# Altium schematic sheet encoderBreakout1.SchDoc
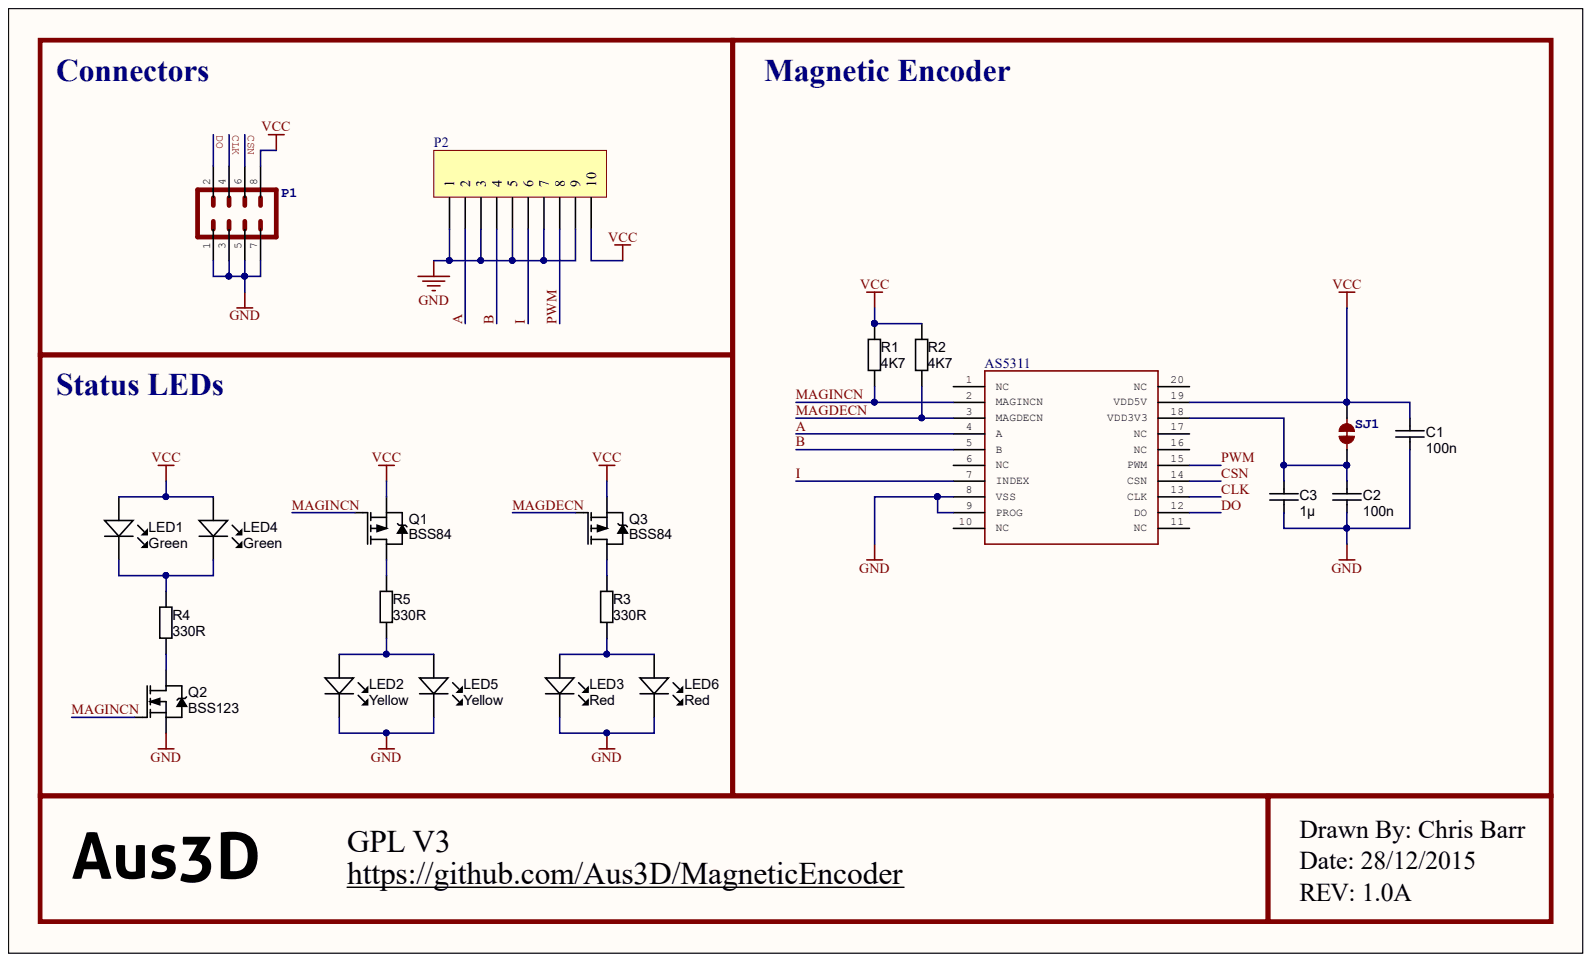
[diagram p0: encoderBreakout1.SchDoc]

## Parts
Aus3D  Aus3D  [Aus3D-Logo]
C1  value 100n  [CAPC1608X90N]  (hidden comment: approved sub OK)
C2  value 100n  [CAPC1608X90N]  (hidden comment: approved sub OK)
C3  value 1µ  [CAPC1608X90N]  (hidden comment: approved sub OK)
LED1  Green  [LED-0603-GREEN]
LED2  Yellow  [LED-0603-YELLOW]
LED3  Red  [LED-0603-RED]
LED4  Green  [LED-0603-GREEN]
LED5  Yellow  [LED-0603-YELLOW]
LED6  Red  [LED-0603-RED]
P1  .1" header, two rows of four.  [2X4]  (value not drawn)
P2  Header 10  [HDR1X10]  (value not drawn)
Q1  BSS84  [SOT23-3]
Q2  BSS123  [SOT23-3]
Q3  BSS84  [SOT23-3]
R1  value 4K7  [RESC1608X55N]  (hidden comment: Thick Film)
R2  value 4K7  [RESC1608X55N]  (hidden comment: Thick Film)
R3  value 330R  [RESC1608X55N]  (hidden comment: Thick Film)
R4  value 330R  [RESC1608X55N]  (hidden comment: Thick Film)
R5  value 330R  [RESC1608X55N]  (hidden comment: Thick Film)
SJ1  JUMPER-PAD-2-NC_BY_TRACE  [PAD-JUMPER-2-NC_BY_TRACE_YES_SILK]  (value not drawn)
U1  AS5311  [TSSOP-20]

## Nets
GND: C1.2 C2.2 C3.2 LED2.K LED3.K LED5.K LED6.K P1.1 P1.3 P1.5 P1.7 P2.1 P2.3 P2.5 P2.7 P2.9 Q2.2 U1.8(VSS) U1.9(PROG)
VCC: C1.1 LED1.A LED4.A P1.8 P2.10 Q1.2 Q3.2 R1.1 R2.1 SJ1.2 U1.19(VDD5V)
A: P2.2 U1.4(A)
B: P2.4 U1.5(B)
CLK: P1.4 U1.13(CLK)
CSN: P1.6 U1.14(CSN)
DO: P1.2 U1.12(DO)
I: P2.6 U1.7(INDEX)
MAGDECN: Q3.1 R2.2 U1.3(MAGDECN)
MAGINCN: Q1.1 Q2.1 R1.2 U1.2(MAGINCN)
PWM: P2.8 U1.15(PWM)
NetC2_1: C2.1 C3.1 SJ1.1 U1.18(VDD3V3)
NetLED1_K: LED1.K LED4.K R4.1
NetLED2_A: LED2.A LED5.A R5.2
NetLED3_A: LED3.A LED6.A R3.2
NetQ1_3: Q1.3 R5.1
NetQ2_3: Q2.3 R4.2
NetQ3_3: Q3.3 R3.1

Not connected: U1 1(NC) 6(NC) 10(NC) 11(NC) 16(NC) 17(NC) 20(NC)

## Library data of the parts
Aus3D = Aus3D Logo: Component Kind: Standard; Library Name: Schlib1.SchLib
C1 C2 = CAPC_100n_0603_X7R_50V_10%: Capacitor SMD Cer 100nF 10% 50V X7R 0603; Manufacturer 1: AVX Corporation; Manufacturer Part Number 1: 06035C104KAT2A; Material: ceramic; Ratings: 50V; X7R; SCDType: spec; Component Kind: Standard; ComponentLink1Description: http://www.avx.com/docs/Catalogs/cx7r.pdf; ComponentLink1URL: http://www.avx.com/docs/Catalogs/cx7r.pdf; ComponentLink2Description: http://product.tdk.com/capacitor/mlcc/en/documents/mlcc_commercial_general_en.pdf; ComponentLink2URL: http://product.tdk.com/capacitor/mlcc/en/documents/mlcc_commercial_general_en.pdf; Library Name: PBL - Capacitors.SCHLIB; Manufacturer 2: TDK Corporation; Manufacturer Part Number 2: C1608X7R1H104K; Package / Case: 0603 (1608 Metric); Supplier 1: Digi-Key; Supplier 2: Digi-Key; Supplier Part Number 1: 478-5052-1-ND; Supplier Part Number 2: 445-1314-1-ND; Tolerance: ±10%
C3 = CAPC_1u_0603_X7R_16V_10%: Capacitor SMD Cer 1uF 10% 16V X7R 0603; Manufacturer 1: TDK Corporation; Manufacturer Part Number 1: C1608X7R1C105K; Material: ceramic; Ratings: 16V; X7R; SCDType: spec; Component Kind: Standard; ComponentLink1Description: http://product.tdk.com/capacitor/mlcc/en/documents/mlcc_commercial_general_en.pdf; ComponentLink1URL: http://product.tdk.com/capacitor/mlcc/en/documents/mlcc_commercial_general_en.pdf; Library Name: PBL - Capacitors.SCHLIB; Package / Case: 0603 (1608 metric); Supplier 1: Digi-Key; Supplier Part Number 1: 445-1604-1-ND; Tolerance: ±10%
LED1 LED4 = LED_SMD_Green_0603_570nm: LED 0603 SMD Green 570nm 50mcd 20mA; Manufacturer 1: Kingbright Company LLC; Manufacturer Part Number 1: APT1608CGCK; Lens Style/Size: Rectangle with Flat Top, 1.1mm x 0.8mm; Lens Type: Clear; Material: AlGaInP; Ratings: 20mcd min; 50 mcd typ; 570 nm; SCDType: source; Viewing Angle: 120°; Voltage - Forward (Vf) @ 10mA: 2.0V; Voltage - Forward (Vf) Typ: 2.1V; Wavelength - Peak: 574nm; Color: Green; Component Kind: Standard; ComponentLink1Description: http://www.us.kingbright.com/images/catalog/SPEC/APT1608CGCK.pdf; ComponentLink1URL: http://www.us.kingbright.com/images/catalog/SPEC/APT1608CGCK.pdf; Current - Test: 20mA; Library Name: PBL - Light Sources.SCHLIB; Package / Case: 0603 (1608 metric); RoHS 1: RoHS Compliant; Supplier 1: Digi-Key; Supplier Part Number 1: 754-1116-1-ND; Wavelength - Dominant: 570nm
LED2 LED5 = LED_SMD_Yellow_0603_150mcd: LED 0603 SMD Yellow 590nm 150mcd 20mA; Manufacturer 1: Kingbright Company LLC; Manufacturer Part Number 1: APT1608SYCK; Material: AlGaInP on GaAs; Ratings: 150 mcd; 590 nm; SCDType: source; Voltage - Forward (Vf) @ 10mA: 1.95V; Voltage - Forward (Vf) Typ: 2V; Component Kind: Standard; ComponentLink1Description: http://www.us.kingbright.com/images/catalog/SPEC/APT1608SYCK.pdf; ComponentLink1URL: http://www.us.kingbright.com/images/catalog/SPEC/APT1608SYCK.pdf; Library Name: PBL - Light Sources.SCHLIB; Package / Case: 0603 (1608 metric); Supplier 1: Digi-Key; Supplier Part Number 1: 754-1124-1-ND
LED3 LED6 = LED_SMD_Red_0603_220mcd: LED 0603 SMD Hyper Red 630nm 220mcd 20mA; Manufacturer 1: Kingbright Company LLC; Manufacturer Part Number 1: APT1608SURCK; Material: AlGaInP on GaAs; Ratings: 220 mcd; 630 nm; SCDType: source; Voltage - Forward (Vf) @ 10mA: 1.9V; Voltage - Forward (Vf) Typ: 1.95V; Component Kind: Standard; ComponentLink1Description: http://www.us.kingbright.com/images/catalog/SPEC/APT1608SURCK.pdf; ComponentLink1URL: http://www.us.kingbright.com/images/catalog/SPEC/APT1608SURCK.pdf; Library Name: PBL - Light Sources.SCHLIB; Package / Case: 0603 (1608 metric); Supplier 1: Digi-Key; Supplier Part Number 1: 754-1123-1-ND
P1 = M04X2: Component Kind: Standard; Library Name: SparkFun-Connectors.IntLib
P2 = Header 10: Header, 10-Pin; Component Kind: Standard; LatestRevisionDate: 17-Jul-2002; LatestRevisionNote: Re-released for DXP Platform.; Library Name: Miscellaneous Connectors.IntLib; Signal Integrity: Connector; Publisher: Altium Limited
Q1 Q3 = MOSFET_BSS84: MOSFET SMD P-CH 50V 130mA SOT23-3; Manufacturer 1: Fairchild Semiconductor; FET Feature: Logic Level Gate; FET Type: MOSFET P-Channel, Metal Oxide; Gate Charge (Qg) @ Vgs: 1.3nC @ 5V; Input Capacitance (Ciss) @ Vds: 73pF @ 25V; Material: Metal Oxide; Power - Max: 360mW; Ratings: 50V; 130mA; Rds On (Max) @ Id, Vgs: 10 Ohm @ 100mA, 5V; SCDType: undef; Vgs(th) (Max) @ Id: 2V @ 1mA; Component Kind: Standard; ComponentLink1Description: http://www.fairchildsemi.com/ds/BS/BSS84.pdf; ComponentLink1URL: http://www.fairchildsemi.com/ds/BS/BSS84.pdf; Current - Continuous Drain (Id) @ 25° C: 130mA; Drain to Source Voltage (Vdss): 50V; Library Name: PBL - Transistors.SCHLIB; Manufacturer Part Number 1: BSS84; Package / Case: SOT23-3; Supplier 1: Digi-Key; Supplier Device Package: SOT-23; Supplier Part Number 1: BSS84CT-ND
Q2 = MOSFET_BSS123: MOSFET SMD N-CH 100V 170mA SOT23-3; Manufacturer 1: Diodes Inc; Manufacturer Part Number 1: BSS123-7-F; Material: Metal Oxide; Ratings: 100V; 170mA; SCDType: source; Component Kind: Standard; Library Name: PBL - Transistors.SCHLIB; Package / Case: SOT23-3; Supplier 1: Digi-Key; Supplier Part Number 1: BSS123-FDICT-ND
R1 R2 = RES_4K7_0603_5%: Resistor SMD chip 4.7k Ohm 0.1W 5% 0603; Manufacturer 1: Vishay/Dale; Manufacturer Part Number 1: CRCW06034K70JNEA; Ratings: 1/10 W; SCDType: spec; Component Kind: Standard; Library Name: PBL - Resistors.SCHLIB; Material: Thick Film; Package / Case: 0603 (1608 metric); Supplier 1: Digi-Key; Supplier Part Number 1: 541-4.7KGCT-ND; Tolerance: ±5%
R3-R5 = RES_330R_0603_5%: Resistor SMD chip 330 Ohm 0.1W 5% 0603; Manufacturer 1: Vishay Dale; Manufacturer Part Number 1: CRCW0603330RJNEA; Ratings: 1/10 W; SCDType: spec; Component Kind: Standard; ComponentLink1Description: http://www.vishay.com/doc?20035; ComponentLink1URL: http://www.vishay.com/doc?20035; ComponentLink2Description: http://www.yageo.com/documents/recent/PYu-RC0603_51_RoHS_L_4.pdf; ComponentLink2URL: http://www.yageo.com/documents/recent/PYu-RC0603_51_RoHS_L_4.pdf; Library Name: PBL - Resistors.SCHLIB; Manufacturer 2: Yageo; Manufacturer Part Number 2: RC0603JR-07330RL; Material: Thick Film; Package / Case: 0603 (1608 metric); Supplier 1: Digi-Key; Supplier 2: Digi-Key; Supplier Part Number 1: 541-330GCT-ND; Supplier Part Number 2: 311-330GRCT-ND; Tolerance: ±5%
U1 = AS5311: AMS's AS5311 linear hall-effect encoder.; Component Kind: Standard; Library Name: ams.IntLib
SJ1 = JUMPER-PAD-2-NC_BY_TRACE: Component Kind: Standard; Library Name: SparkFun-Passives.IntLib
